# Altium schematic sheet PIC32.SchDoc
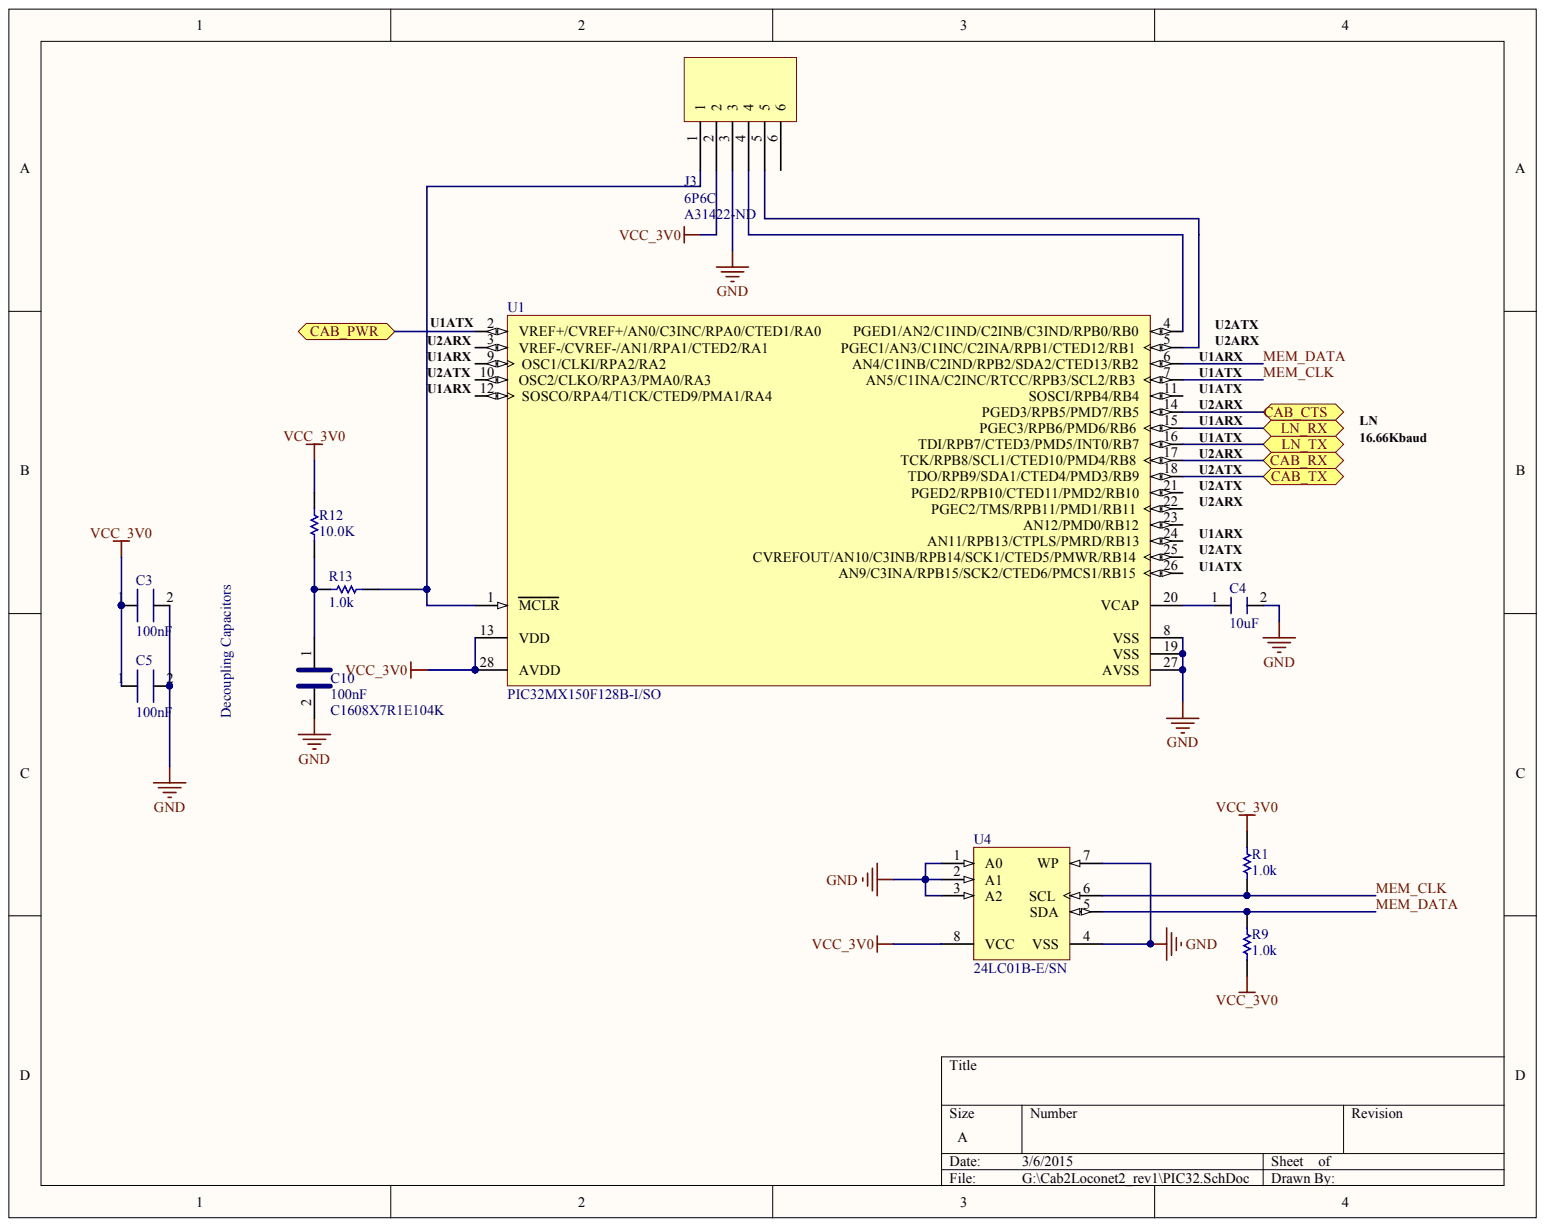
[diagram p0: PIC32.SchDoc]

## Parts
C3  100nF
C4  10uF
C5  100nF
C10  100nF  Manufacturer No: C1608X7R1E104K
J3  6P6C
R1  1.0k
R9  1.0k
R12  10.0K
R13  1.0k
U1  PIC32MX150F128B-I/SO  (value not drawn)
U4  24LC01B-E/SN

## Ports
CAB_CTS, CAB_PWR, CAB_RX, CAB_TX, LN_RX, LN_TX

## Nets
GND: C3.2 C4.2 C5.2 C10.2 J3.3 U1.8(VSS) U1.19(VSS) U1.27(AVSS) U4.1(A0) U4.2(A1) U4.3(A2) U4.4(VSS) U4.7(WP)
VCC_3V0: C3.1 C5.1 J3.2 R1.2 R9.1 R12.2 U1.13(VDD) U1.28(AVDD) U4.8(VCC)
CAB_CTS: U1.14(PGED3/RPB5/PMD7/RB5) ; port CAB_CTS
CAB_PWR: U1.2(VREF+/CVREF+/AN0/C3INC/RPA0/CTED1/RA0) ; port CAB_PWR
CAB_RX: U1.17(TCK/RPB8/SCL1/CTED10/PMD4/RB8) ; port CAB_RX
CAB_TX: U1.18(TDO/RPB9/SDA1/CTED4/PMD3/RB9) ; port CAB_TX
LN_RX: U1.15(PGEC3/RPB6/PMD6/RB6) ; port LN_RX
LN_TX: U1.16(TDI/RPB7/CTED3/PMD5/INT0/RB7) ; port LN_TX
MEM_CLK: R1.1 U1.7(AN5/C1INA/C2INC/RTCC/RPB3/SCL2/RB3) U4.6(SCL)
MEM_DATA: R9.2 U1.6(AN4/C1INB/C2IND/RPB2/SDA2/CTED13/RB2) U4.5(SDA)
NetC4_1: C4.1 U1.20(VCAP)
NetC10_1: C10.1 R12.1 R13.1
NetJ3_1: J3.1 R13.2 U1.1(MCLR)
NetJ3_4: J3.4 U1.4(PGED1/AN2/C1IND/C2INB/C3IND/RPB0/RB0)
NetJ3_5: J3.5 U1.5(PGEC1/AN3/C1INC/C2INA/RPB1/CTED12/RB1)

Not connected: J3 6; U1 3(VREF-/CVREF-/AN1/RPA1/CTED2/RA1) 9(OSC1/CLKI/RPA2/RA2) 10(OSC2/CLKO/RPA3/PMA0/RA3) 11(SOSCI/RPB4/RB4) 12(SOSCO/RPA4/T1CK/CTED9/PMA1/RA4) 21(PGED2/RPB10/CTED11/PMD2/RB10) 22(PGEC2/TMS/RPB11/PMD1/RB11) 23(AN12/PMD0/RB12) 24(AN11/RPB13/CTPLS/PMRD/RB13) 25(CVREFOUT/AN10/C3INB/RPB14/SCK1/CTED5/PMWR/RB14) 26(AN9/C3INA/RPB15/SCK2/CTED6/PMCS1/RB15)

## Library data of the parts
C3 C5 = (no symbol name): Manufacturer: TDK; Manufacturer No: CGA3E3X7S2A104K080AB; Supplier 1: Digi-Key; Supplier Part Number 1: 445-6938-1-ND
C4 = (no symbol name): Manufacturer: Murata; Manufacturer No: GRM188D71A106MA73D; Supplier 1: Digi-Key; Supplier Part Number 1: 490-7200-1-ND
C10 = (no symbol name): Manufacturer: TDK; Supplier 1: Digi-Key; Supplier Part Number 1: 445-1316-2-ND
R1 R9 R13 = (no symbol name): Manufacturer: Panasonic; Manufacturer No: ERJ-3EKF1001V; Supplier 1: Digi-Key; Supplier Part Number 1: P1.00KHCT-ND
R12 = (no symbol name): Manufacturer No: ERJ-3EKF1002V; Manufacturer: Panasonic; Supplier 1: Digi-Key; Supplier Part Number 1: P10.0KHDKR-ND
U1 U4 = (no symbol name): Manufacturer: Microchip; Supplier 1: Digi-Key; Supplier 2: Newark; Supplier 3: Mouser; Mounting Technology: Surface Mount; ComponentLink1Description: Manufacturer URL; ComponentLink2Description: Datasheet; ComponentLink3Description: Package Specification; ComponentLink3URL: http://www.microchip.com/stellent/groups/techpub_sg/documents/packagingspec/en012702.pdf
  U1: DatasheetVersion: Rev. E; PackageVersion: C04-052C; PackageReference: SOIC-SO28; PackageDescription: 28-Lead Plastic Small Outline, 17.9 x 7.5 mm Body, 1.27 mm Pitch; Supplier Part Number 1: PIC32MX150F128B-I/SO-ND; Supplier Part Number 2: 73T1378; Supplier Part Number 3: 579-32MX150F128BISO; PartNumber: PIC32MX150F128B-I/SO; ComponentLink1URL: http://www.microchip.com; ComponentLink2URL: http://ww1.microchip.com/downloads/en/DeviceDoc/61168E.pdf; ComponentLink4Description: Product URL; ComponentLink4URL: http://www.microchip.com/PIC32MX150F128B
  U4: DatasheetVersion: revJ, Jan-2009; PackageVersion: revBB, Aug-2009; PackageReference: SOIC-SN8; PackageDescription: 8-Lead Plastic Small Outline (SN) - Narrow, 3.90mm Body [SOIC]; Supplier Part Number 1: 24LC01B-E/SN-ND; Supplier Part Number 2: 92C3482; Code_JEDEC: MS-012; PartNumber: 24LC01B-E/SN; ComponentLink1URL: http://www.microchip.com/; ComponentLink2URL: http://ww1.microchip.com/downloads/en/DeviceDoc/21711J.pdf; Supplier Part Number 3: 579-24LC01B-E/SN; Code_IPC: SOIC127P600-8
J3 = (no symbol name): Supplier 1: Digi-Key; Supplier Part Number 1: A31422-ND
